# Altium schematic sheet MEMORY_FLASH.SchDoc
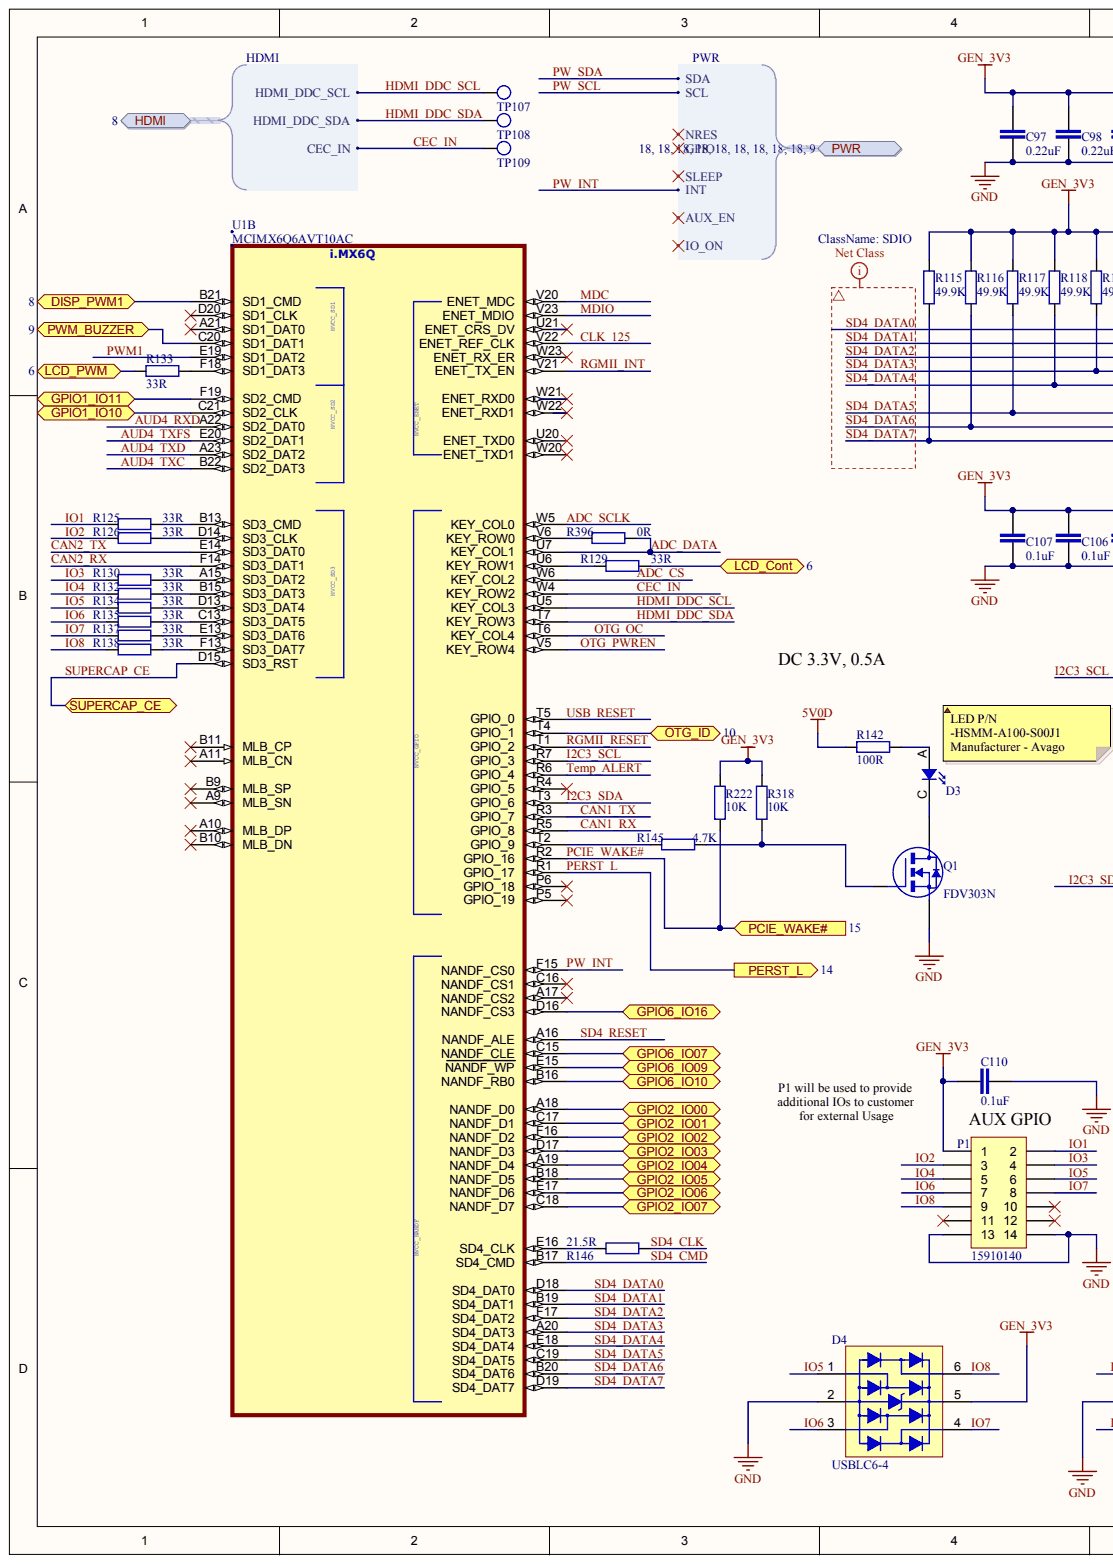
[diagram p0: MEMORY_FLASH.SchDoc, left]
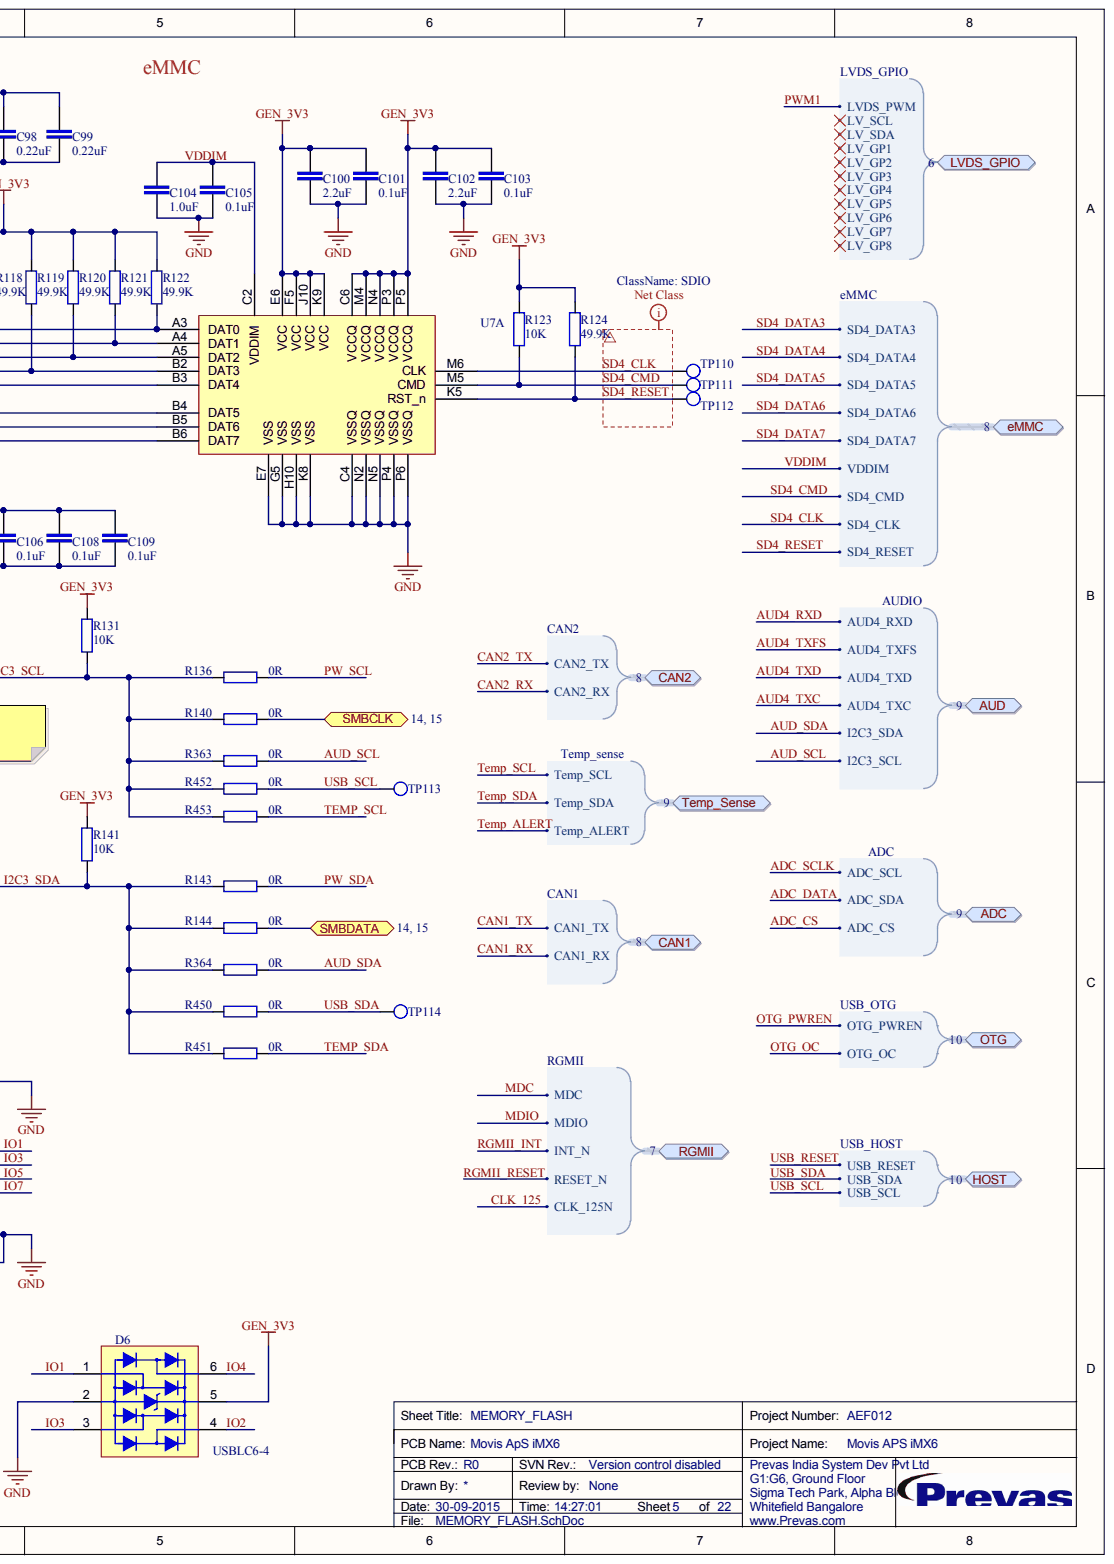
[diagram p1: MEMORY_FLASH.SchDoc, right]

## Parts
C97  0.22uF  [CAPC1005X55N]
C98  0.22uF  [CAPC1005X55N]
C99  0.22uF  [CAPC1005X55N]
C100  2.2uF
C101  0.1uF  [CAPC1005X55N]
C102  2.2uF
C103  0.1uF  [CAPC1005X55N]
C104  1.0uF  [CAPC1608X90N]
C105  0.1uF  [CAPC1005X55N]
C106  0.1uF  [CAPC1005X55N]
C107  0.1uF  [CAPC1005X55N]
C108  0.1uF  [CAPC1005X55N]
C109  0.1uF  [CAPC1005X55N]
C110  0.1uF  [CAPC1005X55N]
D3  5151011F  [OPTCL_LED_PIPE]  (value not drawn)
D4  USBLC6-4  [SOT23-6L]
D6  USBLC6-4  [SOT23-6L]
P1  15910140  [MOLEX_71308-14]
Q1  FDV303N  [SOT95P275X130-3AN]
R115  49.9K  [RESC1608X55N]
R116  49.9K  [RESC1608X55N]
R117  49.9K  [RESC1608X55N]
R118  49.9K  [RESC1608X55N]
R119  49.9K  [RESC1608X55N]
R120  49.9K  [RESC1608X55N]
R121  49.9K  [RESC1608X55N]
R122  49.9K  [RESC1608X55N]
R123  10K  [RESC1608X55N]
R124  49.9K  [RESC1608X55N]
R125  33R  [RESC1608X55N]
R126  33R  [RESC1608X55N]
R129  33R  [RESC1608X55N]
R130  33R  [RESC1608X55N]
R131  10K  [RESC1608X55N]
R132  33R  [RESC1608X55N]
R133  33R  [RESC1608X55N]
R134  33R  [RESC1608X55N]
R135  33R  [RESC1608X55N]
R136  0R  [RESC1608X55N]
R137  33R  [RESC1608X55N]
R138  33R  [RESC1608X55N]
R140  0R  [RESC1608X55N]
R141  10K  [RESC1608X55N]
R142  100R  [RESC1608X55N]
R143  0R  [RESC1608X55N]
R144  0R  [RESC1608X55N]
R145  4.7K  [RESC1608X55N]
R146  21.5R  [RESC1608X55N]
R222  10K  [RESC1608X55N]
R318  10K  [RESC1608X55N]
R363  0R  [RESC1608X55N]
R364  0R  [RESC1608X55N]
R396  0R  [RESC1608X55N]
R450  0R  [RESC1608X55N]
R451  0R  [RESC1608X55N]
R452  0R  [RESC1608X55N]
R453  0R  [RESC1608X55N]
TP107  Testpoint  [TP_SMD]  (value not drawn)  (no BOM)
TP108  Testpoint  [TP_SMD]  (value not drawn)  (no BOM)
TP109  Testpoint  [TP_SMD]  (value not drawn)  (no BOM)
TP110  Testpoint  [TP_SMD]  (value not drawn)  (no BOM)
TP111  Testpoint  [TP_SMD]  (value not drawn)  (no BOM)
TP112  Testpoint  [TP_SMD]  (value not drawn)  (no BOM)
TP113  Testpoint  [TP_SMD]  (value not drawn)  (no BOM)
TP114  Testpoint  [TP_SMD]  (value not drawn)  (no BOM)
U1  MCIMX6Q6AVT10AC  [BGA624C80P25X25_2100X2100X160]  (part B)
U7  MTFC4GACAAAM-1M WT  [BGA153C50P14X14_1155X1305X100]  (value not drawn)  (part A)

## Ports
ADC, ADC.ADC_CS, ADC.ADC_SCL, ADC.ADC_SDA, AUD, AUD.AUD4_RXD, AUD.AUD4_TXC, AUD.AUD4_TXD, AUD.AUD4_TXFS, AUD.I2C3_SCL, AUD.I2C3_SDA, CAN1, CAN1.CAN1_RX, CAN1.CAN1_TX, CAN2, CAN2.CAN2_RX, CAN2.CAN2_TX, DISP_PWM1, EMMC, EMMC.SD4_CLK, EMMC.SD4_CMD, EMMC.SD4_DATA3, EMMC.SD4_DATA4, EMMC.SD4_DATA5, EMMC.SD4_DATA6, EMMC.SD4_DATA7, EMMC.SD4_RESET, EMMC.VDDIM, GPIO1_IO10, GPIO1_IO11, GPIO2_IO00, GPIO2_IO01, GPIO2_IO02, GPIO2_IO03, GPIO2_IO04, GPIO2_IO05, GPIO2_IO06, GPIO2_IO07, GPIO6_IO07, GPIO6_IO09, GPIO6_IO10, GPIO6_IO16, HDMI, HDMI.CEC_IN, HDMI.HDMI_DDC_SCL, HDMI.HDMI_DDC_SDA, HOST, HOST.USB_RESET, HOST.USB_SCL, HOST.USB_SDA, LCD_CONT, LCD_PWM, LVDS_GPIO, LVDS_GPIO.LV_GP1, LVDS_GPIO.LV_GP2, LVDS_GPIO.LV_GP3, LVDS_GPIO.LV_GP4, LVDS_GPIO.LV_GP5, LVDS_GPIO.LV_GP6, LVDS_GPIO.LV_GP7, LVDS_GPIO.LV_GP8, LVDS_GPIO.LV_SCL, LVDS_GPIO.LV_SDA, LVDS_GPIO.LVDS_PWM, OTG, OTG.OTG_OC, OTG.OTG_PWREN, OTG_ID, PCIE_WAKE#, PERST_L, PWM_BUZZER, PWR, PWR.AUX_EN, PWR.GPIO, PWR.INT, PWR.IO_ON, PWR.NRES, PWR.SCL, PWR.SDA, PWR.SLEEP, RGMII, RGMII.CLK_125N, RGMII.INT_N, RGMII.MDC, RGMII.MDIO, RGMII.RESET_N, SMBCLK, SMBDATA, SUPERCAP_CE, TEMP_SENSE, TEMP_SENSE.TEMP_ALERT, TEMP_SENSE.TEMP_SCL, TEMP_SENSE.TEMP_SDA

## Nets
5V0D: R142.1
GEN_3V3: C97.2 C98.2 C99.2 C100.2 C101.2 C102.2 C103.2 C106.2 C107.2 C108.2 C109.2 C110.2 D4.5 D6.5 P1.1 R115.2 R116.2 R117.2 R118.2 R119.2 R120.2 R121.2 R122.2 R123.2 R124.2 R131.2 R141.2 R222.2 R318.2 U7.C6(VCCQ) U7.E6(VCC) U7.F5(VCC) U7.J10(VCC) U7.K9(VCC) U7.M4(VCCQ) U7.N4(VCCQ) U7.P3(VCCQ) U7.P5(VCCQ)
GND: C97.1 C98.1 C99.1 C100.1 C101.1 C102.1 C103.1 C104.1 C105.1 C106.1 C107.1 C108.1 C109.1 C110.1 D4.2 D6.2 P1.13 P1.14 Q1.2 U7.C4(VSSQ) U7.E7(VSS) U7.G5(VSS) U7.H10(VSS) U7.K8(VSS) U7.N2(VSSQ) U7.N5(VSSQ) U7.P4(VSSQ) U7.P6(VSSQ)
ADC_CS: U1.W6(KEY_COL2) ; port ADC
ADC_DATA: R396.2 U1.U7(KEY_COL1) ; port ADC
ADC_SCLK: U1.W5(KEY_COL0) ; port ADC
AUD4_RXD: U1.A22(SD2_DAT0) ; port AUD
AUD4_TXC: U1.B22(SD2_DAT3) ; port AUD
AUD4_TXD: U1.A23(SD2_DAT2) ; port AUD
AUD4_TXFS: U1.E20(SD2_DAT1) ; port AUD
AUD_SCL: R363.2 ; port AUD
AUD_SDA: R364.2 ; port AUD
CAN1_RX: U1.R5(GPIO_8) ; port CAN1
CAN1_TX: U1.R3(GPIO_7) ; port CAN1
CAN2_RX: U1.F14(SD3_DAT1) ; port CAN2
CAN2_TX: U1.E14(SD3_DAT0) ; port CAN2
CEC_IN: TP109.1 U1.W4(KEY_ROW2) ; port HDMI
CLK_125: U1.V22(ENET_REF_CLK) ; port RGMII
DISP_PWM1: U1.B21(SD1_CMD) ; port DISP_PWM1
GPIO1_IO10: U1.C21(SD2_CLK) ; port GPIO1_IO10
GPIO1_IO11: U1.F19(SD2_CMD) ; port GPIO1_IO11
GPIO2_IO00: U1.A18(NANDF_D0) ; port GPIO2_IO00
GPIO2_IO01: U1.C17(NANDF_D1) ; port GPIO2_IO01
GPIO2_IO02: U1.F16(NANDF_D2) ; port GPIO2_IO02
GPIO2_IO03: U1.D17(NANDF_D3) ; port GPIO2_IO03
GPIO2_IO04: U1.A19(NANDF_D4) ; port GPIO2_IO04
GPIO2_IO05: U1.B18(NANDF_D5) ; port GPIO2_IO05
GPIO2_IO06: U1.E17(NANDF_D6) ; port GPIO2_IO06
GPIO2_IO07: U1.C18(NANDF_D7) ; port GPIO2_IO07
GPIO6_IO07: U1.C15(NANDF_CLE) ; port GPIO6_IO07
GPIO6_IO09: U1.E15(NANDF_WP) ; port GPIO6_IO09
GPIO6_IO10: U1.B16(NANDF_RB0) ; port GPIO6_IO10
GPIO6_IO16: U1.D16(NANDF_CS3) ; port GPIO6_IO16
HDMI_DDC_SCL: TP107.1 U1.U5(KEY_COL3) ; port HDMI
HDMI_DDC_SDA: TP108.1 U1.T7(KEY_ROW3) ; port HDMI
I2C3_SCL: R131.1 R136.1 R140.1 R363.1 R452.1 R453.1 U1.R7(GPIO_3)
I2C3_SDA: R141.1 R143.1 R144.1 R364.1 R450.1 R451.1 U1.T3(GPIO_6)
IO1: D6.1 P1.2 R125.1
IO2: D6.4 P1.3 R126.1
IO3: D6.3 P1.4 R130.1
IO4: D6.6 P1.5 R132.1
IO5: D4.1 P1.6 R134.1
IO6: D4.3 P1.7 R135.1
IO7: D4.4 P1.8 R137.1
IO8: D4.6 P1.9 R138.1
LCD_PWM: R133.2 ; port LCD_PWM
MDC: U1.V20(ENET_MDC) ; port RGMII
MDIO: U1.V23(ENET_MDIO) ; port RGMII
OTG_ID: U1.T4(GPIO_1) ; port OTG_ID
OTG_OC: U1.T6(KEY_COL4) ; port OTG
OTG_PWREN: U1.V5(KEY_ROW4) ; port OTG
PCIE_WAKE#: R222.1 U1.R2(GPIO_16) ; port PCIE_WAKE#
PERST_L: U1.R1(GPIO_17) ; port PERST_L
PW_INT: U1.F15(NANDF_CS0) ; port PWR
PW_SCL: R136.2 ; port PWR
PW_SDA: R143.2 ; port PWR
PWM1: U1.E19(SD1_DAT2) ; port LVDS_GPIO
PWM_BUZZER: U1.C20(SD1_DAT1) ; port PWM_BUZZER
RGMII_INT: U1.V21(ENET_TX_EN) ; port RGMII
RGMII_RESET: U1.T1(GPIO_2) ; port RGMII
SD4_CLK: R146.2 TP110.1 U7.M6(CLK) ; port EMMC
SD4_CMD: R123.1 TP111.1 U1.B17(SD4_CMD) U7.M5(CMD) ; port EMMC
SD4_DATA0: R122.1 U1.D18(SD4_DAT0) U7.A3(DAT0)
SD4_DATA1: R121.1 U1.B19(SD4_DAT1) U7.A4(DAT1)
SD4_DATA2: R120.1 U1.F17(SD4_DAT2) U7.A5(DAT2)
SD4_DATA3: R119.1 U1.A20(SD4_DAT3) U7.B2(DAT3) ; port EMMC
SD4_DATA4: R118.1 U1.E18(SD4_DAT4) U7.B3(DAT4) ; port EMMC
SD4_DATA5: R117.1 U1.C19(SD4_DAT5) U7.B4(DAT5) ; port EMMC
SD4_DATA6: R116.1 U1.B20(SD4_DAT6) U7.B5(DAT6) ; port EMMC
SD4_DATA7: R115.1 U1.D19(SD4_DAT7) U7.B6(DAT7) ; port EMMC
SD4_RESET: R124.1 TP112.1 U1.A16(NANDF_ALE) U7.K5(RST_n) ; port EMMC
SMBCLK: R140.2 ; port SMBCLK
SMBDATA: R144.2 ; port SMBDATA
SUPERCAP_CE: U1.D15(SD3_RST) ; port SUPERCAP_CE
TEMP_ALERT: U1.R6(GPIO_4) ; port TEMP_SENSE
TEMP_SCL: R453.2 ; port TEMP_SENSE
TEMP_SDA: R451.2 ; port TEMP_SENSE
USB_RESET: U1.T5(GPIO_0) ; port HOST
USB_SCL: R452.2 TP113.1 ; port HOST
USB_SDA: R450.2 TP114.1 ; port HOST
VDDIM: C104.2 C105.2 U7.C2(VDDIM) ; port EMMC
NetD3_A: D3.A R142.2
NetD3_C: D3.C Q1.3
NetQ1_1: Q1.1 R145.1 R318.1
NetR125_2: R125.2 U1.B13(SD3_CMD)
NetR126_2: R126.2 U1.D14(SD3_CLK)
NetR129_1: R129.1 U1.U6(KEY_ROW1)
NetR129_2: R129.2 ; port LCD_CONT
NetR130_2: R130.2 U1.A15(SD3_DAT2)
NetR132_2: R132.2 U1.B15(SD3_DAT3)
NetR133_1: R133.1 U1.F18(SD1_DAT3)
NetR134_2: R134.2 U1.D13(SD3_DAT4)
NetR135_2: R135.2 U1.C13(SD3_DAT5)
NetR137_2: R137.2 U1.E13(SD3_DAT6)
NetR138_2: R138.2 U1.F13(SD3_DAT7)
NetR145_2: R145.2 U1.T2(GPIO_9)
NetR146_1: R146.1 U1.E16(SD4_CLK)
NetR396_1: R396.1 U1.V6(KEY_ROW0)
N00330: ; port LVDS_GPIO
N00331: ; port LVDS_GPIO
N00332: ; port LVDS_GPIO
N00333: ; port LVDS_GPIO
N00334: ; port LVDS_GPIO
N00335: ; port LVDS_GPIO
N00336: ; port LVDS_GPIO
N00337: ; port LVDS_GPIO
N00338: ; port LVDS_GPIO
N00339: ; port LVDS_GPIO
N00340:
N00341:
N00342: ; port PWR
N00343: ; port PWR
N00344: ; port PWR
N00345: ; port PWR
N00346: ; port PWR

Not connected: P1 10 11 12; U1 A9(MLB_SN) A10(MLB_DP) A11(MLB_CN) A17(NANDF_CS2) A21(SD1_DAT0) B9(MLB_SP) B10(MLB_DN) B11(MLB_CP) C16(NANDF_CS1) D20(SD1_CLK) P5(GPIO_19) P6(GPIO_18) R4(GPIO_5) U20(ENET_TXD0) U21(ENET_CRS_DV) W20(ENET_TXD1) W21(ENET_RXD0) W22(ENET_RXD1) W23(ENET_RX_ER)

## Library data of the parts
C97-C99 = innisi-76: CAP CER 0.22UF 16V 10% X7R 0402; ref_part_type: /capacitor/non_polarized/ceramic/; source_manufacturer_1: Murata; source_manufacturer_1_pn: GRM155R71C224KA12D; cae_altium_pcb_status: Prototype; Database Table Name: altium_default; db_rev: 7572; elec_temp_range: -55 to +125C; phy_height: 0.55mm; phy_mounting: SMD; phy_packaging_type: 0402; ref_datasheet: INNISI-73; ref_keyword: Ceramic capacitor X7R 0402; cae_altium_sch_status: Prototype; Component Kind: Standard; ComponentLink1Description: Datasheet; ComponentLink1URL: https://cop201.prevas.dk/viewvc/datasheet/INNISI-73.pdf?root=hw_components&view=co; db_created: 2015-08-03 04:28:56.0; db_last_updated: 03-08-2015 04:28:58; db_local_id: INNISI-76; db_status: Prototype; elec_tolerance: 10%; elec_value: 0.22uF; elec_voltage_rating: 16V; phy_material: X7R; Library Name: altium_mysql.dblib
C100 C102 = HKDS-75: Ceramic capacitor X5R 0603; ref_part_type: /capacitor/non_polarized/ceramic/; source_manufacturer_1: Yageo; source_manufacturer_1_pn: CC0603KRX5R6BB225; cae_altium_sch_status: Prototype; Database Table Name: altium_default; db_rev: 6782; elec_temp_range: -55 to +85C; elec_tolerance: 10%; elec_voltage_rating: 10V; phy_height: 0.8mm; phy_mounting: SMD; phy_packaging_type: 0603; ref_datasheet: HKDS-142; ref_keyword: Multilayer chip capacitor; Component Kind: Standard; ComponentLink1Description: Datasheet; ComponentLink1URL: https://cop201.prevas.dk/viewvc/datasheet/HKDS-142.pdf?root=hw_components&view=co; db_created: 2014-09-05 09:06:36.0; db_last_updated: 05-09-2014 09:07:36; db_local_id: HKDS-75; db_status: Prototype; elec_value: 2.2uF; phy_material: X5R; Library Name: altium_mysql.dblib
C101 C103 C105-C110 = INNISI-73: CAP CER 0.1UF 50V 10% X5R 0402; ref_part_type: /capacitor/non_polarized/ceramic/; source_manufacturer_1: TDK Corporation; source_manufacturer_1_pn: C1005X5R1H104K050BB; cae_altium_pcb_status: Prototype; Database Table Name: altium_default; db_rev: 7569; elec_temp_range: -55 to +85 C; phy_height: 0.55mm; phy_mounting: SMD; phy_packaging_type: 0402; ref_datasheet: INNISI-71; cae_altium_sch_status: Prototype; Component Kind: Standard; ComponentLink1Description: Datasheet; ComponentLink1URL: https://cop201.prevas.dk/viewvc/datasheet/INNISI-71.pdf?root=hw_components&view=co; db_created: 2015-08-03 03:34:59.0; db_last_updated: 03-08-2015 03:35:01; db_local_id: INNISI-73; db_status: Prototype; elec_tolerance: ±10%; elec_value: 0.1uF; elec_voltage_rating: 50V; phy_material: X5R; ref_keyword: CAP CER 0.1UF 50V 10% X5R 0402; Library Name: altium_mysql.dblib
C104 = INNISI-79: CAP CER 1UF 16V 10% X7R 0603; ref_part_type: /capacitor/non_polarized/ceramic/; source_manufacturer_1: Murata; source_manufacturer_1_pn: GRM188R71C105KE15D; cae_altium_pcb_status: Prototype; Database Table Name: altium_default; db_rev: 7576; elec_temp_range: -55 to +125; phy_height: 0.90mm; phy_mounting: SMD; phy_packaging_type: 0603 (1608 Metric); ref_datasheet: INNISI-33; ref_keyword: Ceramic Capacitor 0603; cae_altium_sch_status: Prototype; Component Kind: Standard; ComponentLink1Description: Datasheet; ComponentLink1URL: https://cop201.prevas.dk/viewvc/datasheet/INNISI-33.pdf?root=hw_components&view=co; db_created: 2015-08-03 05:39:06.0; db_last_updated: 03-08-2015 05:39:08; db_local_id: INNISI-79; db_status: Prototype; elec_tolerance: 10%; elec_value: 1.0uF; elec_voltage_rating: 16V; phy_material: X7R; Library Name: altium_mysql.dblib
D3 = INNISI-60: LIGHT PIPE SINGLE ELEMENT; ref_part_type: /optical/emmision/; source_manufacturer_1: Dialight; cae_altium_pcb_status: Prototype; Database Table Name: altium_default; db_rev: 7455; elec_temp_range: -30C - +100C; phy_mounting: Leaded; ref_datasheet: INNISI-58; cae_altium_sch_status: Prototype; Component Kind: Standard; ComponentLink1Description: Datasheet; ComponentLink1URL: https://cop201.prevas.dk/viewvc/datasheet/INNISI-58.pdf?root=hw_components&view=co; db_created: 2015-06-17 06:24:46.0; db_last_updated: 17-06-2015 06:24:48; db_local_id: INNISI-60; db_status: Prototype; elec_value: 5151011F; ref_keyword: Light Pipes; source_manufacturer_1_pn: 5151011F; Library Name: altium_mysql.dblib
D4 D6 = NIMA-155: Dual very low capacitance ESD protection for USB 2.0 up to 480Mb/s; ref_part_type: /diode/transient/; source_manufacturer_1: STMicroelectronics; cae_altium_pcb_status: Prototype; Database Table Name: altium_default; db_rev: 1817; elec_temp_range: -40 to 125; elec_voltage_rating: 5V; phy_height: 1.45mm; phy_mounting: SMD; ref_datasheet: NIMA-115; cae_altium_sch_status: Prototype; Component Kind: Standard; ComponentLink1Description: Datasheet; ComponentLink1URL: https://cop201.prevas.dk/viewvc/datasheet/NIMA-115.pdf?root=hw_components&view=co; db_created: 2009-09-25 21:20:59; db_last_updated: 25-09-2009 21:21:20; db_local_id: NIMA-155; db_status: Prototype; elec_value: USBLC6-4; Mounted: Yes; phy_packaging_type: SOT23-6L; ref_keyword: ESD; source_manufacturer_1_pn: USBLC6-4; Library Name: altium_mysql.dblib
P1 = MITO-19: Header 2x7 pins 2.54mm pitch; ref_part_type: /connector/14 pins/; source_manufacturer_1: Molex; cae_altium_pcb_status: Prototype; Database Table Name: altium_default; db_rev: 579; elec_temp_range: -40 to +105°C; elec_voltage_rating: 250V; phy_height: 10.16mm; phy_material: High temperature thermoplastic; phy_mounting: SMD; phy_packaging_type: Dual row; ref_datasheet: MITO-17; cae_altium_sch_status: Prototype; Component Kind: Standard; ComponentLink1Description: Datasheet; ComponentLink1URL: https://cop201.prevas.dk/viewvc/datasheet/MITO-17.pdf?root=hw_components&view=co; db_created: 2008-10-09 12:48:22; db_last_updated: 19-11-2008 11:38:39; db_local_id: MITO-19; db_status: Prototype; elec_value: 15910140; ref_keyword: Header; source_manufacturer_1_pn: 15910140; Library Name: altium_mysql.dblib
Q1 = JEPE-131: Digital FET, N-channel; ref_part_type: /transistor/mosfet/n_channel/; source_manufacturer_1: Fairchild; cae_altium_pcb_status: Prototype; Database Table Name: altium_default; db_rev: 1864; elec_current_rating: 0.68A; elec_power_rating: 0.35W; elec_temp_range: -55 to 155; elec_voltage_rating: 25V; phy_height: 1.30mm; phy_mounting: SMD; phy_packaging_type: SOT-23; ref_datasheet: JEPE-88; cae_altium_sch_status: Prototype; Component Kind: Standard; ComponentLink1Description: Datasheet; ComponentLink1URL: https://cop201.prevas.dk/viewvc/datasheet/JEPE-88.pdf?root=hw_components&view=co; db_created: 2008-08-21 13:48:45; db_last_updated: 14-10-2009 13:08:45; db_local_id: JEPE-131; db_status: Prototype; elec_value: FDV303N; ref_keyword: Digital FET, N-channel; source_manufacturer_1_pn: FDV303N; Library Name: altium_mysql.dblib
R115-R122 R124 = INVIHA-12: RES SMD 49.9K OHM 1% 1/10W 0603; ref_part_type: /resistor/fixed/; source_manufacturer_1: Yageo; source_manufacturer_1_pn: RC0603FR-0749K9L; cae_altium_pcb_status: Prototype; Database Table Name: altium_default; db_rev: 7592; elec_power_rating: 0.1W; elec_temp_range: -55 to +155° C; phy_height: 0.55mm; phy_packaging_type: 0603; ref_datasheet: INVIHA-2; ref_keyword: Chip Resistor; cae_altium_sch_status: Prototype; Component Kind: Standard; ComponentLink1Description: Datasheet; ComponentLink1URL: https://cop201.prevas.dk/viewvc/datasheet/INVIHA-2.pdf?root=hw_components&view=co; db_created: 2015-08-03 06:44:06.0; db_last_updated: 03-08-2015 6:44:08 AM; db_local_id: INVIHA-12; db_status: Prototype; elec_tolerance: 1%; elec_value: 49.9K; phy_mounting: SMD; Library Name: altium_mysql.dblib
R123 R131 R141 R222 R318 = INVIHA-3: RES SMD 10K OHM 1% 1/10W 0603; ref_part_type: /resistor/fixed/; source_manufacturer_1: Yageo; source_manufacturer_1_pn: RC0603FR-0710KL; cae_altium_pcb_status: Prototype; Database Table Name: altium_default; db_rev: 7575; elec_power_rating: 0.1W; elec_temp_range: -55 to +155° C; phy_height: 0.55mm; phy_packaging_type: 0603; ref_datasheet: INVIHA-2; ref_keyword: Chip Resistor; cae_altium_sch_status: Prototype; Component Kind: Standard; ComponentLink1Description: Datasheet; ComponentLink1URL: https://cop201.prevas.dk/viewvc/datasheet/INVIHA-2.pdf?root=hw_components&view=co; db_created: 2015-08-03 05:39:03.0; db_last_updated: 03-08-2015 5:39:05 AM; db_local_id: INVIHA-3; db_status: Prototype; elec_tolerance: 1%; elec_value: 10K; phy_mounting: SMD; Library Name: altium_mysql.dblib
R125 R126 R129 R130 R132-R135 R137 R138 = INVIHA-13: RES SMD 33 OHM 1% 1/10W 0603; ref_part_type: /resistor/fixed/; source_manufacturer_1: Yageo; source_manufacturer_1_pn: RC0603FR-0733RL; cae_altium_pcb_status: Prototype; Database Table Name: altium_default; db_rev: 7593; elec_power_rating: 0.1W; elec_temp_range: -55 to +155° C; phy_height: 0.55mm; phy_packaging_type: 0603; ref_datasheet: INVIHA-2; ref_keyword: Chip Resistor; cae_altium_sch_status: Prototype; Component Kind: Standard; ComponentLink1Description: Datasheet; ComponentLink1URL: https://cop201.prevas.dk/viewvc/datasheet/INVIHA-2.pdf?root=hw_components&view=co; db_created: 2015-08-03 06:49:16.0; db_last_updated: 03-08-2015 6:49:17 AM; db_local_id: INVIHA-13; db_status: Prototype; elec_tolerance: 1%; elec_value: 33R; phy_mounting: SMD; Library Name: altium_mysql.dblib
R136 R140 R143 R144 R363 R364 R396 R450-R453 = INVIHA-4: RES SMD 0.0 OHM JUMPER 1/10W; ref_part_type: /resistor/fixed/; source_manufacturer_1: Panasonic Electronic Components; source_manufacturer_1_pn: ERJ-3GEY0R00V; cae_altium_pcb_status: Prototype; Database Table Name: altium_default; db_rev: 7580; elec_power_rating: 0.1W; elec_temp_range: -55 to +155° C; phy_height: 0.55mm; phy_packaging_type: 0603; ref_datasheet: INVIHA-3; ref_keyword: Chip Resistor; cae_altium_sch_status: Prototype; Component Kind: Standard; ComponentLink1Description: Datasheet; ComponentLink1URL: https://cop201.prevas.dk/viewvc/datasheet/INVIHA-3.pdf?root=hw_components&view=co; db_created: 2015-08-03 05:59:19.0; db_last_updated: 03-08-2015 5:59:21 AM; db_local_id: INVIHA-4; db_status: Prototype; elec_value: 0R; phy_mounting: SMD; Library Name: altium_mysql.dblib
R142 = INVIHA-6: RES SMD 100 OHM 1% 1/10W 0603; ref_part_type: /resistor/fixed/; source_manufacturer_1: Yageo; source_manufacturer_1_pn: RC0603FR-07100RL; cae_altium_pcb_status: Prototype; Database Table Name: altium_default; db_rev: 7583; elec_power_rating: 0.1W; elec_temp_range: -55 to +155° C; phy_height: 0.55mm; phy_packaging_type: 0603; ref_datasheet: INVIHA-2; ref_keyword: Chip Resistor; cae_altium_sch_status: Prototype; Component Kind: Standard; ComponentLink1Description: Datasheet; ComponentLink1URL: https://cop201.prevas.dk/viewvc/datasheet/INVIHA-2.pdf?root=hw_components&view=co; db_created: 2015-08-03 06:19:06.0; db_last_updated: 03-08-2015 6:19:07 AM; db_local_id: INVIHA-6; db_status: Prototype; elec_tolerance: 1%; elec_value: 100R; phy_mounting: SMD; Library Name: altium_mysql.dblib
R145 = INVIHA-5: RES SMD 4.7K OHM 1% 1/10W 0603; ref_part_type: /resistor/fixed/; source_manufacturer_1: Yageo; source_manufacturer_1_pn: RC0603FR-074K7L; cae_altium_pcb_status: Prototype; Database Table Name: altium_default; db_rev: 7581; elec_power_rating: 0.1W; elec_temp_range: -55 to +155° C; phy_height: 0.55mm; phy_packaging_type: 0603; ref_datasheet: INVIHA-4; ref_keyword: Chip Resistor; cae_altium_sch_status: Prototype; Component Kind: Standard; ComponentLink1Description: Datasheet; ComponentLink1URL: https://cop201.prevas.dk/viewvc/datasheet/INVIHA-4.pdf?root=hw_components&view=co; db_created: 2015-08-03 06:09:48.0; db_last_updated: 03-08-2015 6:09:49 AM; db_local_id: INVIHA-5; db_status: Prototype; elec_tolerance: 1%; elec_value: 4.7K; phy_mounting: SMD; Library Name: altium_mysql.dblib
R146 = INVIHA-14: RES SMD 21.5 OHM 1% 1/10W 0603; ref_part_type: /resistor/fixed/; source_manufacturer_1: Yageo; source_manufacturer_1_pn: RC0603FR-0721R5L; cae_altium_pcb_status: Prototype; Database Table Name: altium_default; db_rev: 7595; elec_power_rating: 0.1W; elec_temp_range: -55 to +155° C; phy_height: 0.55mm; phy_packaging_type: 0603; ref_datasheet: INVIHA-2; ref_keyword: Chip Resistor; cae_altium_sch_status: Prototype; Component Kind: Standard; ComponentLink1Description: Datasheet; ComponentLink1URL: https://cop201.prevas.dk/viewvc/datasheet/INVIHA-2.pdf?root=hw_components&view=co; db_created: 2015-08-03 06:53:36.0; db_last_updated: 03-08-2015 6:53:38 AM; db_local_id: INVIHA-14; db_status: Prototype; elec_tolerance: 1%; elec_value: 21.5R; phy_mounting: SMD; Library Name: altium_mysql.dblib
TP107-TP114 = JEPE-114: Test Point SMD; ref_part_type: /pcb_item/; cae_altium_pcb_status: Prototype; Database Table Name: altium_default; db_rev: 3281; cae_altium_sch_status: Prototype; ComponentLink1Description: Datasheet; db_created: 2008-08-18 11:39:42; db_last_updated: 23-03-2011 14:14:06; db_local_id: JEPE-114; db_status: Prototype; elec_value: Test Point; phy_mounting: SMD; ref_keyword: Test  Point; Library Name: altium_mysql.dblib
U1 = INRAAN-5: i.MX 6Dual/6Quad; ref_part_type: /integrated/digital/processor/general/; AGILE_PN: 344-01218; CAD_APPROVED: Yes; cae_altium_pcb_status: Prototype; CLASS: IC; Database Table Name: altium_default; db_rev: 6247; elec_temp_range: -40 to +105; elec_voltage_rating: 1.5V; MFG_NAME01: FREESCALE SEMICONDUCTOR; phy_height: 1.85; phy_mounting: SMD; phy_packaging_type: FCPBGA96_624; ref_datasheet: INRAAN-6; cae_altium_sch_status: Prototype; Component Kind: Standard; ComponentLink1Description: Datasheet; ComponentLink1URL: https://cop201.prevas.dk/viewvc/datasheet/INRAAN-6.pdf?root=hw_components&view=co; db_created: 2013-10-21 12:25:57.0; db_last_updated: 27-12-2013 09:32:57; db_local_id: INRAAN-5; db_status: Prototype; elec_value: MCIMX6Q6AVT10AC; HEIGHT: 2.16MM; MFG_PN01: MCIMX6Q6AVT10AC; ref_keyword: i.MX 6Dual/6Quad; ROHS: Yes; source_manufacturer_1: Freescale Semiconductor; source_manufacturer_1_pn: MCIMX6Q6AVT10AC; Library Name: altium_mysql.dblib
U7 = INNISI-7: eMMC Memory,4GB; ref_part_type: /integrated/digital/memory/non_volatile/eraseable/flash/; source_manufacturer_1: Micron; cae_altium_pcb_status: Prototype; Database Table Name: altium_default; db_rev: 7323; elec_temp_range: –25?C to +85?C; elec_voltage_rating: 2.7–3.6V; phy_mounting: SMD; phy_packaging_type: 153-ball VFBGA; cae_altium_sch_status: Prototype; Component Kind: Standard; ComponentLink1Description: Datasheet; ComponentLink1URL: https://cop201.prevas.dk/viewvc/datasheet/INNISI-7.pdf?root=hw_components&view=co; db_created: 2015-05-27 06:58:23.0; db_last_updated: 27-05-2015 06:58:25; db_local_id: INNISI-7; db_status: Prototype; elec_value: MTFC4GACAAAM-1M WT; ref_datasheet: INNISI-7; ref_keyword: eMMC Memory; source_manufacturer_1_pn: MTFC4GACAAAM-1M WT; Library Name: altium_mysql.dblib
